# Altium schematic sheet Com_Peripherals.SchDoc
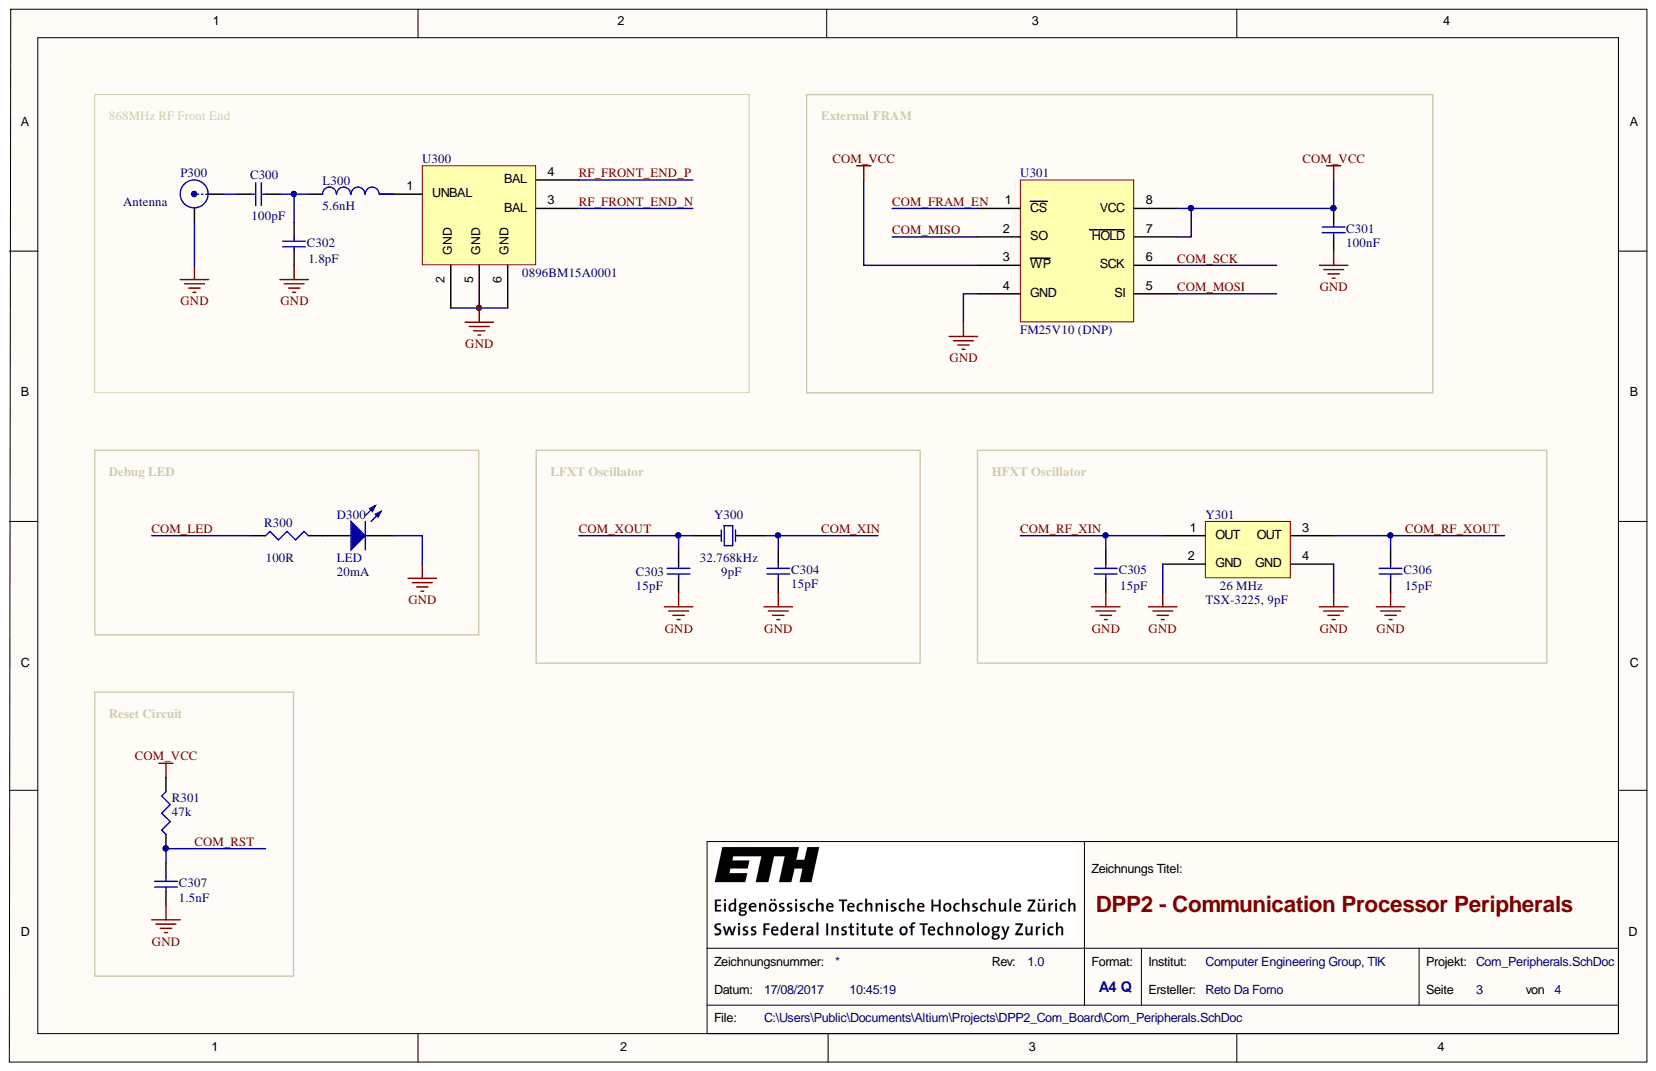
[diagram p0: Com_Peripherals.SchDoc]

## Parts
C300  100pF  [0402_N]
C301  100nF  [0402_N]
C302  1.8pF  [0402_N]
C303  15pF  [0402_N]
C304  15pF  [0402_N]
C305  15pF  [0402_N]
C306  15pF  [0402_N]
C307  1.5nF  [0402_N]
D300  LED  If: 20mA  [0603_LED2]
L300  5.6nH  [0402_N]
P300  Antenna  [SMA_PCB_Small]
R300  100R  [0402_N]
R301  47k  [0402_N]
U300  0896BM15A0001  [CC110L_BALUN]
U301  FM25V10 (DNP)  [SOIC8_MS_012]
Y300  32.768kHz  LoadCapacitance: 9pF  [FC_135]
Y301  26 MHz  [TSX_3225]

## Nets
COM_VCC: C301.1 R301.2 U301.3(WP) U301.7(HOLD) U301.8(VCC)
GND: C301.2 C302.2 C303.2 C304.2 C305.2 C306.2 C307.2 D300.2 P300.2 U300.2(GND) U300.5(GND) U300.6(GND) U301.4(GND) Y301.2(GND) Y301.4(GND)
COM_FRAM_EN: U301.1(CS)
COM_LED: R300.1
COM_MISO: U301.2(SO)
COM_MOSI: U301.5(SI)
COM_RF_XIN: C305.1 Y301.1(OUT)
COM_RF_XOUT: C306.1 Y301.3(OUT)
COM_RST: C307.1 R301.1
COM_SCK: U301.6(SCK)
COM_XIN: C304.1 Y300.2
COM_XOUT: C303.1 Y300.1
RF_FRONT_END_N: U300.3(BAL)
RF_FRONT_END_P: U300.4(BAL)
NetC300_1: C300.1 P300.1
NetC300_2: C300.2 C302.1 L300.1
NetD300_1: D300.1 R300.2
NetL300_2: L300.2 U300.1(UNBAL)

## Library data of the parts
C300 = Cap: Capacitor, Ceramic; Manufacturer: Murata; PartNumber: GRM1555C1H101FA01D; Component Kind: Standard; LatestRevisionDate: 17-Jul-2002; LatestRevisionNote: Re-released for DXP Platform.; PackageDescription: Radial Cap, Thru-Hole; 2 Leads; 0.3 in Pin Spacing; PackageReference: RAD-0.3; Published: 8-Jun-2000; Signal Integrity: Cap; Simulation: CAP; Library Name: PermaSense.SchLib; Publisher: Altium Limited
C301 = Cap: Capacitor, Ceramic; Component Kind: Standard; LatestRevisionDate: 17-Jul-2002; LatestRevisionNote: Re-released for DXP Platform.; PackageDescription: Radial Cap, Thru-Hole; 2 Leads; 0.3 in Pin Spacing; PackageReference: RAD-0.3; Published: 8-Jun-2000; Signal Integrity: Cap; Simulation: CAP; Library Name: PermaSense.SchLib; Publisher: Altium Limited
C302 = Cap: Capacitor, Ceramic; Manufacturer: Johanson; PartNumber: 500R07S1R8BV4T; Component Kind: Standard; LatestRevisionDate: 17-Jul-2002; LatestRevisionNote: Re-released for DXP Platform.; PackageDescription: Radial Cap, Thru-Hole; 2 Leads; 0.3 in Pin Spacing; PackageReference: RAD-0.3; Published: 8-Jun-2000; Signal Integrity: Cap; Simulation: CAP; Library Name: PermaSense.SchLib; Publisher: Altium Limited
C303 C304 = Cap: Capacitor; Manufacturer: Murata; PartNumber: GRM1555C1H150JA01D; Component Kind: Standard; LatestRevisionDate: 17-Jul-2002; LatestRevisionNote: Re-released for DXP Platform.; Library Name: Miscellaneous Devices.IntLib; PackageReference: RAD-0.3; Published: 8-Jun-2000; Publisher: Altium Limited
C305 C306 = Cap: Capacitor, Ceramic; Manufacturer: Murata; PartNumber: GRM1555C1H150JA01D; Component Kind: Standard; LatestRevisionDate: 17-Jul-2002; LatestRevisionNote: Re-released for DXP Platform.; PackageDescription: Radial Cap, Thru-Hole; 2 Leads; 0.3 in Pin Spacing; PackageReference: RAD-0.3; Published: 8-Jun-2000; Signal Integrity: Cap; Simulation: CAP; Library Name: PermaSense.SchLib; Publisher: Altium Limited
C307 = Cap: Capacitor; Manufacturer: Murata; PartNumber: GRM155R71H152JA01D; Component Kind: Standard; LatestRevisionDate: 17-Jul-2002; LatestRevisionNote: Re-released for DXP Platform.; Library Name: Miscellaneous Devices.IntLib; PackageReference: RAD-0.3; Published: 8-Jun-2000; Publisher: Altium Limited
D300 = LED0: Typical INFRARED GaAs LED; Component Kind: Standard; Library Name: Miscellaneous Devices.IntLib
L300 = Inductor: Manufacturer: Johanson; PartNumber: L-07C5N6SV6T; Code_IEC: 1005; Code_JEITA: 0402; Component Kind: Standard; Description: Inductor; LatestRevisionDate: 29-May-2009; LatestRevisionNote: IPC-7351 Footprint Added.; Library Name: Miscellaneous Devices.IntLib; PackageDescription: Chip Inductor; PackageReference: 0402-A; Published: 8-Jun-2000; Signal Integrity: Inductor; Simulation: INDUCTOR; Publisher: Altium Limited
P300 = SMB: SMB Straight Connector; Manufacturer: Multicomp; PartNumber: 19-70-4-TGG; Component Kind: Standard; LatestRevisionDate: 17-Jul-2002; LatestRevisionNote: Re-released for DXP Platform.; Library Name: Miscellaneous Connectors.IntLib; Publisher: Altium Limited
R300 = Res3: Resistor; If: 20mA; Vf: 2V; Component Kind: Standard; LatestRevisionDate: 29-May-2009; LatestRevisionNote: IPC-7351 Footprint Added.; Library Name: Miscellaneous Devices.IntLib; PackageDescription: Chip Resistor; PackageReference: J1-0603; Published: 8-Jun-2000; Publisher: Altium Limited
R301 = Res3: Resistor; Component Kind: Standard; LatestRevisionDate: 29-May-2009; LatestRevisionNote: IPC-7351 Footprint Added.; Library Name: Miscellaneous Devices.IntLib; PackageDescription: Chip Resistor; PackageReference: J1-0603; Published: 8-Jun-2000; Publisher: Altium Limited
U300 = 0433BM15A0001: Johanson Technology 433MHz Balun for TI CC110L; Component Kind: Standard; Manufacturer: Johanson; PartNumber: 0896BM15A0001; Library Name: Felix_Misc_Components.SchLib
U301 = FM25V10: Cypress 1Mbit Serial FRAM; Component Kind: Standard; Manufacturer: Cypress; Library Name: Felix_Component_Library.SchLib
Y300 = FC-135: Crystal Oscillator, 32.768kHz, 9pF; Manufacturer: Epson; PartNumber: FC-135 32.7680KA-AC3; Component Kind: Standard; Supplier 1: Digi-Key; Supplier 2: Digi-Key; Supplier 3: Farnell; Supplier Part Number 1: SE2413DKR-ND; Supplier Part Number 2: SE2413CT-ND; Supplier Part Number 3: 1278036; Temperature: -40 +85; Library Name: PermaSense.SchLib
Y301 = TSX-3225: Oscillator, Epson, 26MHz for CC430; PartNumber: TSX-3225 26.0000MF09Z-AC3; Component Kind: Standard; Manufacturer: Epson; PartNumber2: TSX-3225; Library Name: PermaSense.SchLib
